# Altium schematic sheet controller_8266.SchDoc
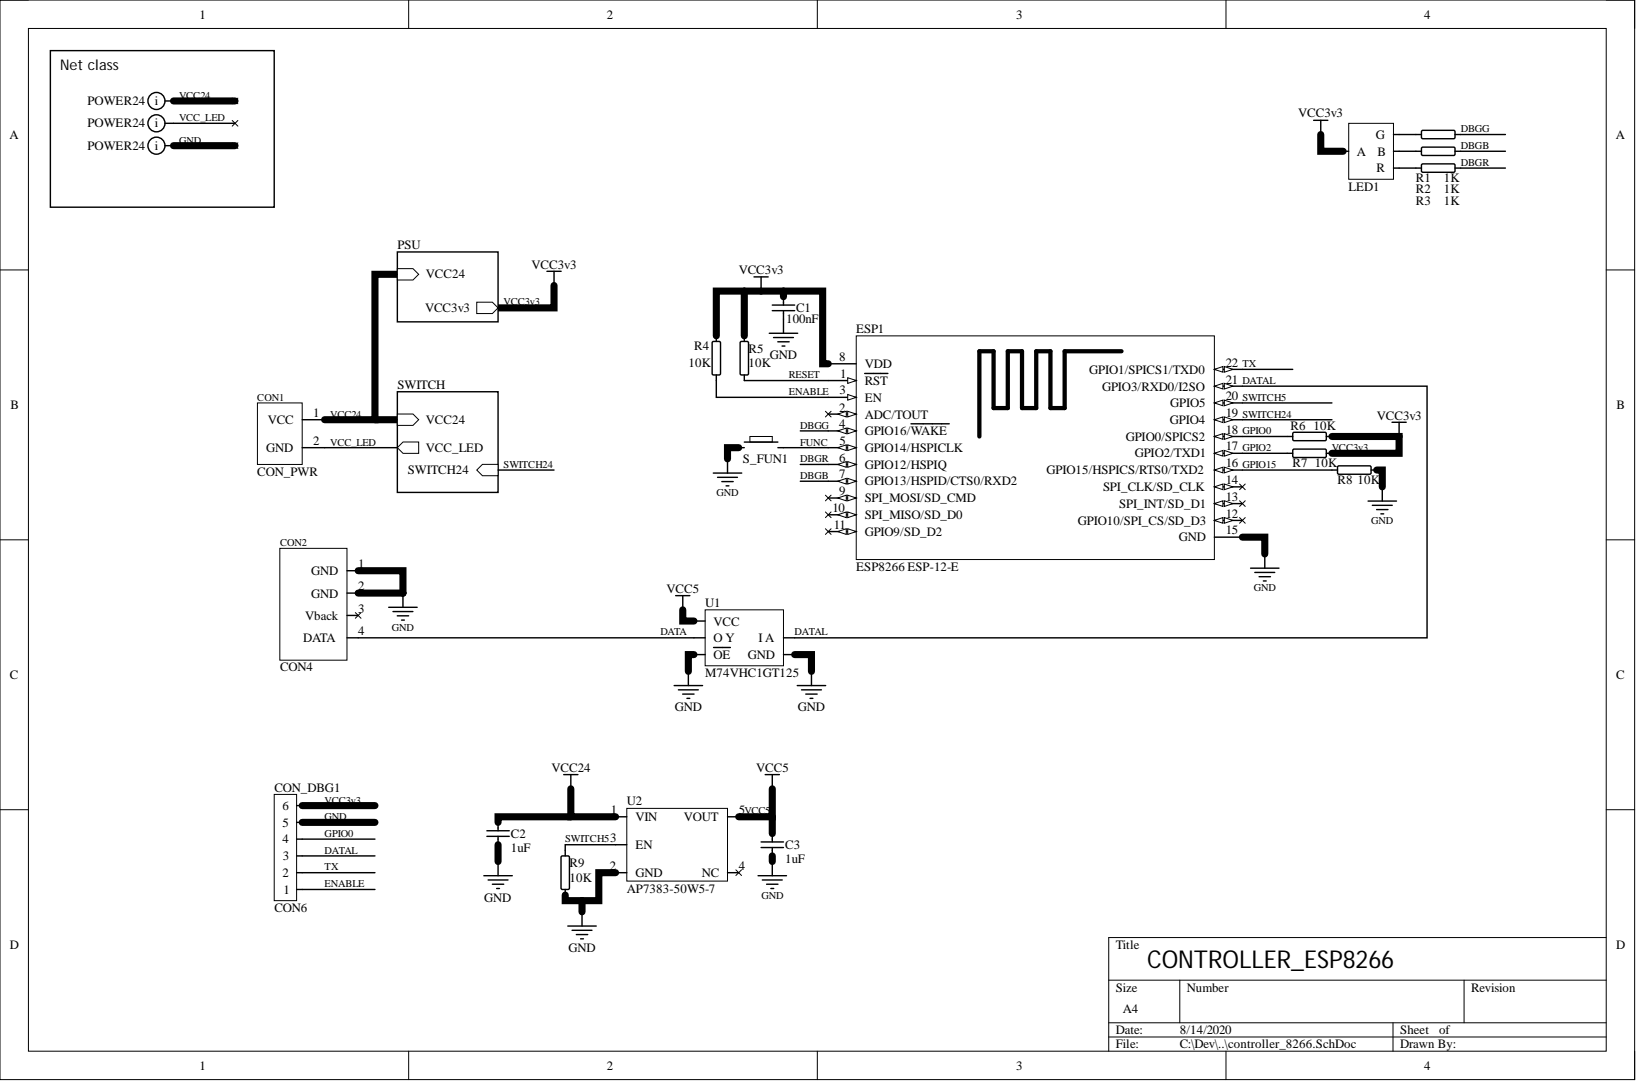
[diagram p0: controller_8266.SchDoc]

## Parts
C1  value 100nF  [CAPC_0603_1608X08L]  (hidden comment: Cap)
C2  value 1uF  [CAPC_0603_1608X08L]  (hidden comment: Cap)
C3  value 1uF  [CAPC_0603_1608X08L]  (hidden comment: Cap)
CON1  CON_PWR  [CONPWR]  (no BOM)
CON2  CON4  [CONNECTOR]  (no BOM)
CON_DBG1  CON6  [CON2X3]  (no BOM)
ESP1  ESP8266 ESP-12-E  [ESP8266 ESP-12-E]
LED1  RGB LED Common anode  [PLCC-4]  (value not drawn)
R1  value 1K  [RESC_0603_1608X08L]  (hidden comment: Res2)
R2  value 1K  [RESC_0603_1608X08L]  (hidden comment: Res2)
R3  value 1K  [RESC_0603_1608X08L]  (hidden comment: Res2)
R4  value 10K  [RESC_0603_1608X08L]  (hidden comment: Res2)
R5  value 10K  [RESC_0603_1608X08L]  (hidden comment: Res2)
R6  value 10K  [RESC_0603_1608X08L]  (hidden comment: Res2)
R7  value 10K  [RESC_0603_1608X08L]  (hidden comment: Res2)
R8  value 10K  [RESC_0603_1608X08L]  (hidden comment: Res2)
R9  value 10K  [RESC_0603_1608X08L]  (hidden comment: Res2)
S_FUN1  4-1437565-1  [EVQP7C01P]  (value not drawn)
U1  M74VHC1GT125  [SOT65P210X100-5L]
U2  AP7383-50W5-7  [SOT95P260X90-5L]

## Nets
DATA: CON2.4(DATA) U1.4(O_Y)
DATAL: CON_DBG1.3 ESP1.21(GPIO3/RXD0/I2SO) U1.2(I_A)
DBGB: ESP1.7(GPIO13/HSPID/CTS0/RXD2) R2.2
DBGG: ESP1.4(GPIO16/WAKE) R1.2
DBGR: ESP1.6(GPIO12/HSPIQ) R3.2
ENABLE: CON_DBG1.1 ESP1.3(EN) R4.2
FUNC: ESP1.5(GPIO14/HSPICLK) S_FUN1.1
GND: C1.2 C2.1 C3.1 CON2.1(GND) CON2.2(GND) CON_DBG1.5 ESP1.15(GND) R8.2 R9.1 S_FUN1.2 U1.1(OE) U1.3(GND) U2.2(GND)
GPIO0: CON_DBG1.4 ESP1.18(GPIO0/SPICS2) R6.1
GPIO2: ESP1.17(GPIO2/TXD1) R7.1
GPIO15: ESP1.16(GPIO15/HSPICS/RTS0/TXD2) R8.1
RESET: ESP1.1(RST) R5.1
SWITCH5: ESP1.20(GPIO5) R9.2 U2.3(EN)
SWITCH24: ESP1.19(GPIO4)
TX: CON_DBG1.2 ESP1.22(GPIO1/SPICS1/TXD0)
VCC3v3: C1.1 CON_DBG1.6 ESP1.8(VDD) LED1.2(A) R4.1 R5.2 R6.2 R7.2
VCC5: C3.2 U1.5(VCC) U2.5(VOUT)
VCC24: C2.2 CON1.1 U2.1(VIN)
VCC_LED: CON1.2
NetCON2_3: CON2.3(Vback)
NetESP1_2: ESP1.2(ADC/TOUT)
NetESP1_9: ESP1.9(SPI_MOSI/SD_CMD)
NetESP1_10: ESP1.10(SPI_MISO/SD_D0)
NetESP1_11: ESP1.11(GPIO9/SD_D2)
NetESP1_12: ESP1.12(GPIO10/SPI_CS/SD_D3)
NetESP1_13: ESP1.13(SPI_INT/SD_D1)
NetESP1_14: ESP1.14(SPI_CLK/SD_CLK)
NetLED1_1: LED1.1(R) R3.1
NetLED1_3: LED1.3(G) R1.1
NetLED1_4: LED1.4(B) R2.1
NetU2_4: U2.4(NC)

## Library data of the parts
C1-C3 = Cap: Capacitor; Component Kind: Standard; LatestRevisionDate: 17-Jul-2002; LatestRevisionNote: Re-released for DXP Platform.; Library Name: controller_8266.SchLib; PackageDescription: Radial Cap, Thru-Hole; 2 Leads; 0.3 in Pin Spacing; PackageReference: RAD-0.3; Published: 8-Jun-2000; Publisher: Altium Limited
CON1 = CON_PWR: Header 2 way; Library Name: controller_8266.SchLib
CON2 = CON4: Header 4 way; Library Name: controller_8266.SchLib
CON_DBG1 = CON6: Debug header 6 way; Library Name: controller_8266.SchLib
LED1 = RGB_LED: Dot is _not_ anode!; Component Kind: Standard; Library Name: controller_8266.SchLib
R1 = Res2: Resistor; Value: 1K; Component Kind: Standard; LatestRevisionDate: 17-Jul-2002; LatestRevisionNote: Re-released for DXP Platform.; Library Name: controller_8266.SchLib; PackageDescription: Axial Device, Thru-Hole; 2 Leads; 0.4 in Pin Spacing; PackageReference: AXIAL-0.4; Published: 8-Jun-2000; Publisher: Altium Limited
R2-R9 = Res2: Resistor; Component Kind: Standard; LatestRevisionDate: 17-Jul-2002; LatestRevisionNote: Re-released for DXP Platform.; Library Name: controller_8266.SchLib; PackageDescription: Axial Device, Thru-Hole; 2 Leads; 0.4 in Pin Spacing; PackageReference: AXIAL-0.4; Published: 8-Jun-2000; Publisher: Altium Limited
S_FUN1 = 4-1437565-1: Tactile switch; Manufacturer_Name: TE Connectivity; Allied_Number: R1117787; Component Kind: Standard; Datasheet Link: http://uk.rs-online.com/web/p/products/1616046; Library Name: controller_8266.SchLib; Manufacturer_Part_Number: 4-1437565-1; RS Part Number: 1616046
U1 = M74VHC1GT125: Level shifter non inverting; Component Kind: Standard; Library Name: controller_8266.SchLib
U2 = AP7383-50W5-7: 5V LDO Vin_max 33V 150mA; Component Kind: Standard; Library Name: controller_8266.SchLib
ESP1 = ESP8266 ESP-12-E: Component Kind: Standard; Description: ESP8266 ESP-12-E; Library Name: controller_8266.SchLib
